# Altium schematic sheet Synaps_USB_V1I2.SchDoc
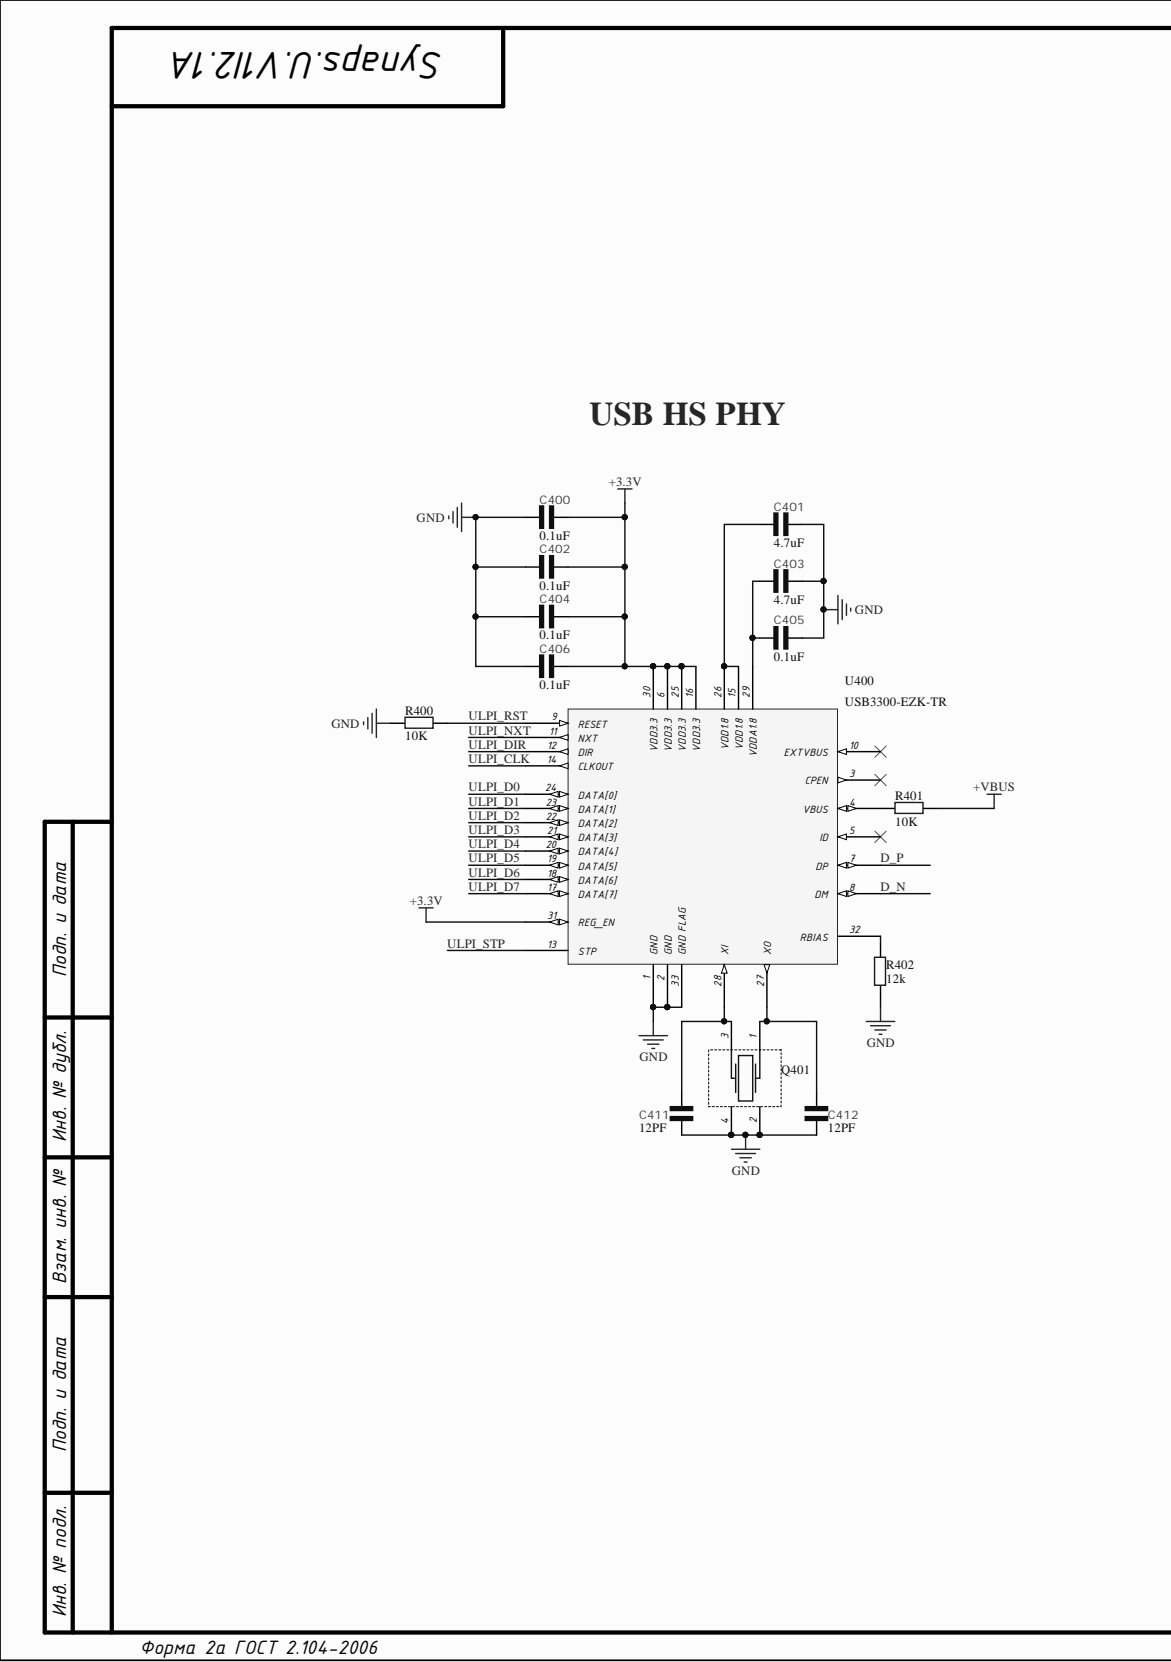
[diagram p0: Synaps_USB_V1I2.SchDoc, left]
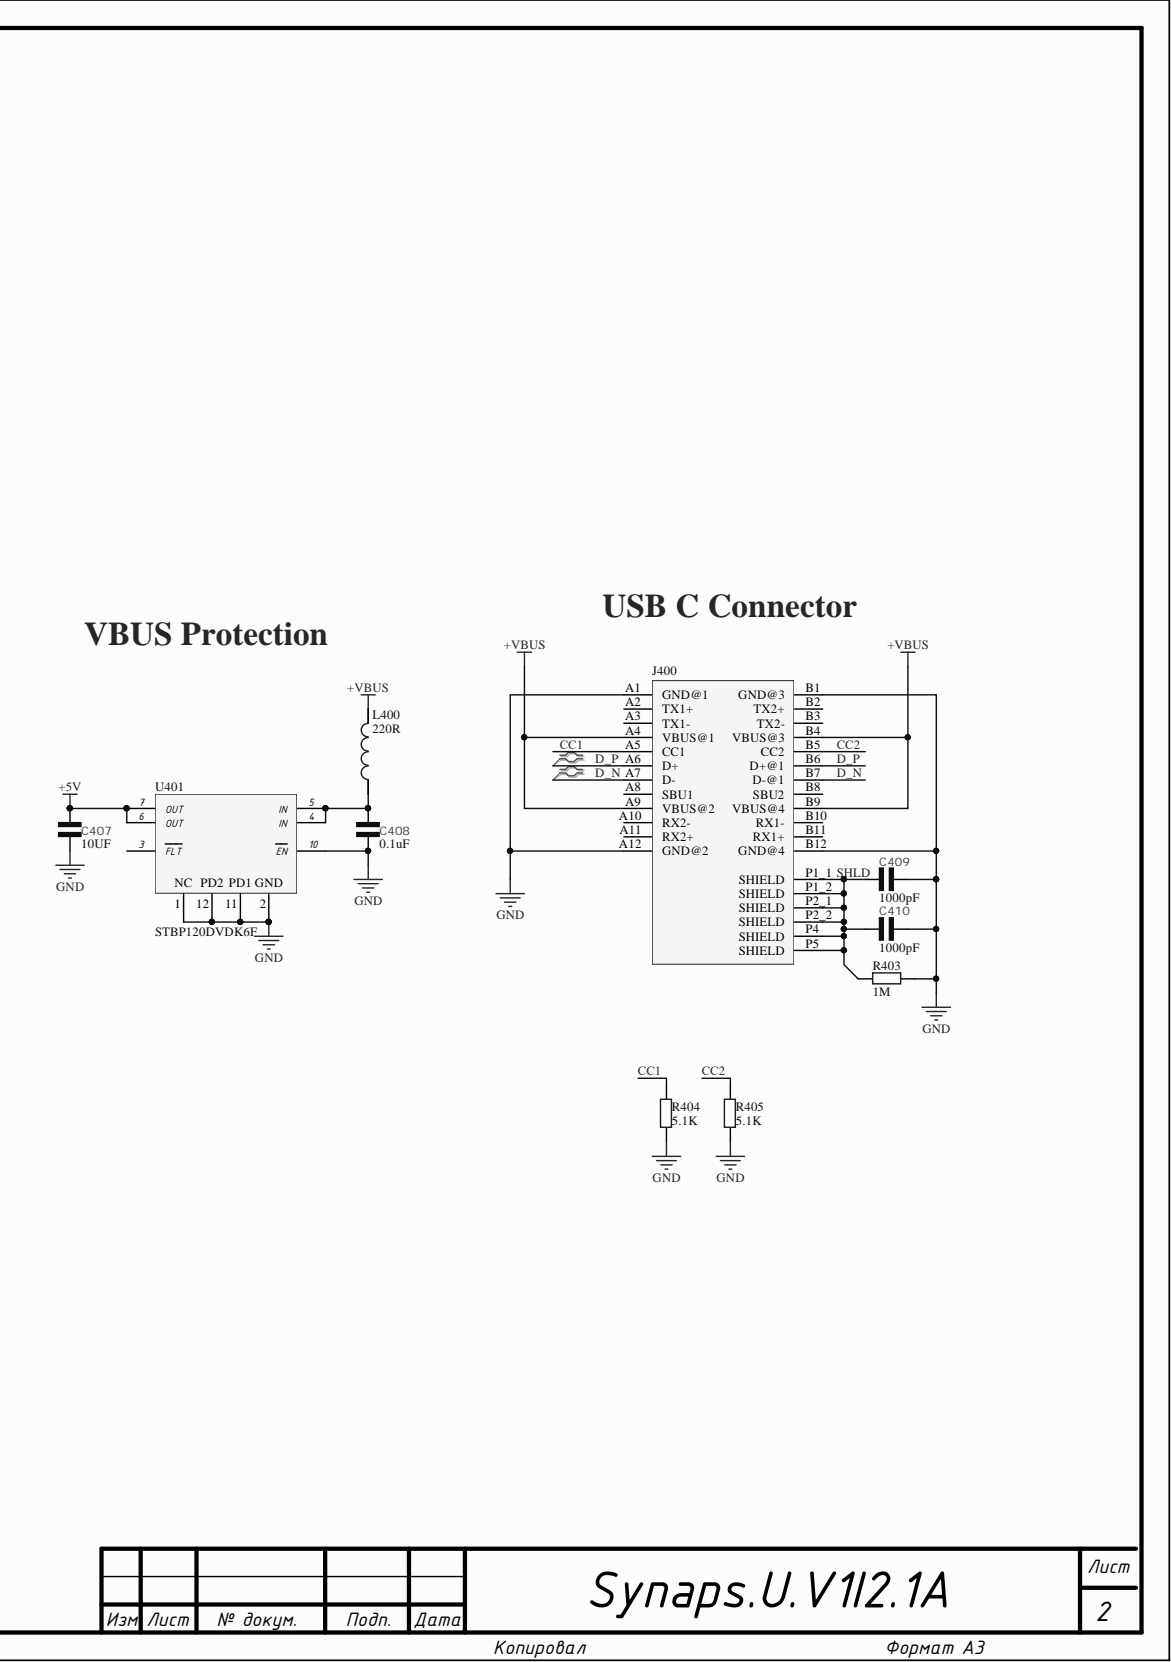
[diagram p1: Synaps_USB_V1I2.SchDoc, right]

## Parts
C400  value 0.1uF  [C0402]  (hidden comment: GRM155R71A104KA01D)
C401  value 4.7uF  Capacitance: 4.7uF  [C0603]  (hidden comment: CEJMK107BJ475KA-T)
C402  value 0.1uF  [C0402]  (hidden comment: GRM155R71A104KA01D)
C403  value 4.7uF  Capacitance: 4.7uF  [C0603]  (hidden comment: CEJMK107BJ475KA-T)
C404  value 0.1uF  [C0402]  (hidden comment: GRM155R71A104KA01D)
C405  value 0.1uF  [C0402]  (hidden comment: GRM155R71A104KA01D)
C406  value 0.1uF  [C0402]  (hidden comment: GRM155R71A104KA01D)
C407  value 10UF  [C1206]  (hidden comment: CL31B106KAHNNNE)
C408  value 0.1uF  [C0402]  (hidden comment: GRM155R71A104KA01D)
C409  value 1000pF  Capacitance: 1000pF  [C1206]  (hidden comment: 202R18W102KV4E)
C410  value 1000pF  Capacitance: 1000pF  [C1206]  (hidden comment: 202R18W102KV4E)
C411  value 12PF  [C0603]  (hidden comment: CL10C120JB8NNNC)
C412  value 12PF  [C0603]  (hidden comment: CL10C120JB8NNNC)
J400  2295018-2  [USB-C-1]  (value not drawn)
L400  value 220R  [L0603]  (hidden comment: CIC10P221NC)
Q401  XRCGB24M000F3M00R0  [XRCGB]  (value not drawn)
R400  value 10K  [R0402]  (hidden comment: RC0402FR-0710KL)
R401  value 10K  [R0402]  (hidden comment: RC0402FR-0710KL)
R402  value 12k  [R0402]  (hidden comment: AC0402FR-0712KL)
R403  value 1M  Resistance (Ohms): 1M  [R0603]  (hidden comment: RC0603FR-071ML)
R404  value 5.1K  [R0603]  (hidden comment: RC0603FR-075K1L)
R405  value 5.1K  [R0603]  (hidden comment: RC0603FR-075K1L)
U400  USB3300-EZK-TR  [QFN32-5x5]
U401  STBP120DVDK6F  [TDFN10-2.5x2.0x0.75p0.50]

## Nets
+3.3V: C400.2 C402.2 C404.2 C406.2 U400.6(VDD3.3) U400.16(VDD3.3) U400.25(VDD3.3) U400.30(VDD3.3) U400.31(REG_EN)
+5V: C407.2 U401.6(OUT) U401.7(OUT)
+VBUS: J400.A4(VBUS@1) J400.A9(VBUS@2) J400.B4(VBUS@3) J400.B9(VBUS@4) L400.2 R401.2
GND: C400.1 C401.2 C402.1 C403.2 C404.1 C405.2 C406.1 C407.1 C408.1 C409.2 C410.2 C411.1 C412.1 J400.A1(GND@1) J400.A12(GND@2) J400.B1(GND@3) J400.B12(GND@4) Q401.2 Q401.4 R400.1 R402.1 R403.2 R404.1 R405.1 U400.1(GND) U400.2(GND) U400.33(GND) U401.1(NC) U401.2 U401.10(EN) U401.11 U401.12
D_N: J400.A7(D-) J400.B7(D-@1) U400.8(DM)
D_P: J400.A6(D+) J400.B6(D+@1) U400.7(DP)
SHLD: C409.1 C410.1 J400.P1_1(SHIELD) J400.P1_2(SHIELD) J400.P2_1(SHIELD) J400.P2_2(SHIELD) J400.P4(SHIELD) J400.P5(SHIELD) R403.1
ULPI_CLK: U400.14(CLKOUT)
ULPI_D0: U400.24(DATA[0])
ULPI_D1: U400.23(DATA[1])
ULPI_D2: U400.22(DATA[2])
ULPI_D3: U400.21(DATA[3])
ULPI_D4: U400.20(DATA[4])
ULPI_D5: U400.19(DATA[5])
ULPI_D6: U400.18(DATA[6])
ULPI_D7: U400.17(DATA[7])
ULPI_DIR: U400.12(DIR)
ULPI_NXT: U400.11(NXT)
ULPI_RST: R400.2 U400.9(RESET)
ULPI_STP: U400.13(STP)
СС1: J400.A5(CC1) R404.2
СС2: J400.B5(CC2) R405.2
NetC401_1: C401.1 U400.15(VDD1.8) U400.26(VDD1.8)
NetC403_1: C403.1 C405.1 U400.29(VDDA1.8)
NetC408_2: C408.2 L400.1 U401.4(IN) U401.5(IN)
NetC411_2: C411.2 Q401.3 U400.28(XI)
NetC412_2: C412.2 Q401.1 U400.27(XO)
NetR401_1: R401.1 U400.4(VBUS)
NetR402_2: R402.2 U400.32(RBIAS)

Not connected: J400 A2(TX1+) A3(TX1-) A8(SBU1) A10(RX2-) A11(RX2+) B2(TX2+) B3(TX2-) B8(SBU2) B10(RX1-) B11(RX1+); U400 3(CPEN) 5(ID) 10(EXTVBUS); U401 3(FLT)

## Library data of the parts
C400 C402 C404-C406 C408 = GRM155R71A104KA01D: CAP CER 0.1uF 10V X7R 0402; Manufacturer: Murata; Capacitance: 100nF; Database Table Name: Capacitors_chip; Tolerance: 10%; Category: Capacitors; Component Kind: Standard; ComponentLink1Description: Datasheet; ComponentLink1URL: https://datasheet.ciiva.com/18184/getdatasheetpartid-130330-18184636.pdf; Component Type: Standard; Cost: 0,002541; Designator: C?; Library Name: AIComponents.DbLib; Part Number: GRM155R71A104KA01D; Stock: 10000; Termination: SMD/SMT; Voltage: 10V
C401 C403 = CEJMK107BJ475KA-T: Cap Ceramic 4.7uF 6.3V X5R 10% Pad SMD 0603 85°C T/R; Manufacturer: Taiyo Yuden; Database Table Name: Capacitors_chip; Category: Capacitors; Component Kind: Standard; Component Type: Standard; Cost: 0,0044802; Designator: C?; Library Name: AIComponents.DbLib; Part Number: CEJMK107BJ475KA-T; Pin Count: 2; Stock: 3970; Temperature Coefficie: X5R; Tolerance: ±10%; Voltage: 6.3V
C407 = CL31B106KAHNNNE: CAP CER 10UF 25V X7R 1206; Manufacturer: SAMSUNG ELECTRO-MECHANICS AMERICA, INC; Capacitance: 10µF; Database Table Name: Capacitors_chip; Tolerance: ±10%; Applications: General Purpose; Category: Capacitors; Component Kind: Standard; ComponentLink1Description: Datasheet; ComponentLink1URL: http://www.samsungsem.com/kr/support/product-search/mlcc/__icsFiles/afieldfile/2016/08/18/S_CL31B106KAHNNNE.pdf; Component Type: Standard; Cost: 0,0157181192832308; Designator: C?; Features: -; Height - Seated (Max): -; Lead Spacing: -; Lead Style: -; Library Name: AIComponents.DbLib; Mounting Type: Surface Mount, MLCC; Operating Temperature: -55°C ~ 125°C; Package / Case: 1206 (3216 Metric); Part Number: CL31B106KAHNNNE; Pin Count: 2; Ratings: -; Size / Dimension: 0.126" L x 0.063" W (3.20mm x 1.60mm); Stock: 1914; Temperature Coefficie: X7R; Temperature Coefficient: X7R; Thickness (Max): 0.071" (1.80mm); Voltage: 25V; Voltage - Rated: 25V
C409 C410 = 202R18W102KV4E: CAP CER 1000PF 2KV X7R 1206; Manufacturer: Johanson Dielectrics Inc.; Database Table Name: Capacitors_chip; Tolerance: ±10%; Voltage - Rated: 2000V; Category: Capacitors; Component Kind: Standard; ComponentLink1Description: Datasheet; ComponentLink1URL: https://www.johansondielectrics.com/downloads/jdi-product-catalog.pdf; Component Type: Standard; Cost: 0; Library Name: AIComponents.DbLib; Part Number: 202R18W102KV4E; Pin Count: 2; Stock: 0; Temperature Coefficie: X7R; Temperature Coefficient: X7R; Voltage: 2kV
C411 C412 = CL10C120JB8NNNC: CAP CER 12PF 50V NP0 0603; Manufacturer: SAMSUNG ELECTRO-MECHANICS AMERICA, INC; Database Table Name: Capacitors_chip; Temperature Coefficient: C0G, NP0; Tolerance: ±5%; Applications: General Purpose; Capacitance: 12pF; Category: Capacitors; Component Kind: Standard; ComponentLink1Description: Datasheet; ComponentLink1URL: http://www.samsungsem.com/kr/support/product-search/mlcc/__icsFiles/afieldfile/2016/08/18/S_CL10C120JB8NNNC.pdf; Component Type: Standard; Cost: 0,00216226822322155; Designator: C?; Features: -; Height - Seated (Max): -; Lead Spacing: -; Lead Style: -; Library Name: AIComponents.DbLib; Mounting Type: Surface Mount, MLCC; Operating Temperature: -55°C ~ 125°C; Package / Case: 0603 (1608 Metric); Part Number: CL10C120JB8NNNC; Pin Count: 2; Ratings: -; Size / Dimension: 0.063" L x 0.031" W (1.60mm x 0.80mm); Stock: 4084; Temperature Coefficie: NP0; Thickness (Max): 0.035" (0.90mm); Voltage: 50V; Voltage - Rated: 50V
J400 = 2295018-2: SPLASH PROOF USB TYPE C REC, DUA; Manufacturer: TE Connectivity AMP Connectors; Centerline_Pitch: .5 mm[.019 in]; Database Table Name: Connectors; MF: TE Connectivity; Number_of_Positions: 24; Product_Type: Connector; Component Kind: Standard; ComponentLink1Description: Drawing; ComponentLink1URL: http://www.te.com/commerce/DocumentDelivery/DDEController?Action=srchrtrv&DocNm=2295018&DocType=Customer+Drawing&DocLang=English; Component Type: Standard; Designator: J?; Digi-Key_Part_Number: A124377CT-ND; Digi-Key_Purchase_URL: https://www.digikey.com/product-detail/en/te-connectivity-amp-connectors/2295018-2/A124377CT-ND/6047824?utm_source=snapeda&utm_medium=aggregator&utm_campaign=symbol; EU_RoHS_Compliance: Compliant; Library Name: AIComponents.DbLib; Link: http://www.te.com/usa-en/product-2295018-2.html; MP: 2295018-2; Package: None; Part Number: 2295018-2; Pin Count: 24; SnapEDA_Link: https://www.snapeda.com/parts/2295018-2/TE%20Connectivity%20AMP%20Connectors/view-part/?ref=snap
L400 = CIC10P221NC: FERRITE BEAD 220 OHM 0603 1LN; Manufacturer: Samsung; Current: 1.4A; Database Table Name: Inductivities; DC Resistance (DCR) (Max): 100 mOhm; Filter Type: Power Line; Impedance @ Frequency: 220 Ohm @ 100MHz; Number of Lines: 1; Category: Filters; Component Kind: Standard; ComponentLink1Description: http://www.samsungsem.com/kr/front/downloadcms.do?path=/kr/support/product-search/bead/__icsFiles/afieldfile/2014/11/13&fileName=S_CIC10J121NC.pdf; ComponentLink1URL: http://www.samsungsem.com/kr/front/downloadcms.do?path=/kr/support/product-search/bead/__icsFiles/afieldfile/2014/11/13&fileName=S_CIC10J121NC.pdf; Component Type: Standard; Cost: 0,00715; Current Rating (Max): 1.4A; Designator: FB?; Height (Max): 0.037" (0.95mm); Library Name: AIComponents.DbLib; Mounting Type: Surface Mount; Operating Temperature: -55°C ~ 125°C; Package / Case: 0603 (1608 Metric); Part Number: CIC10P221NC; Pin Count: 2; Pricing 2: 1=0.1, 10=0.062, 25=0.0568, 50=0.051, 100=0.0374, 250=0.0272, 500=0.02492, 1000=0.02153, 5000=0.017 (USD); Ratings: -; Size / Dimension: 0.063" L x 0.031" W (1.60mm x 0.80mm); Stock: 3583; Stock 2: 30743; Supplier 2: Digi-Key; Supplier Part Number 2: 490-9701-1-ND
Q401 = XRCGB24M000F3M00R0: CRYSTAL 24.0000MHZ 6PF SMD; Manufacturer: Murata Electronics North America; Database Table Name: Resonators; Value: 24MHz; Component Kind: Standard; ComponentLink1Description: Datasheet; ComponentLink1URL: https://www.murata.com/~/media/webrenewal/campaign/ads/america/timing/p79e.ashx?la=en-us; Component Type: Standard; Designator: Q?; Library Name: AIComponents.DbLib; Part Number: XRCGB24M000F3M00R0; Pin Count: 4
R400 R401 = RC0402FR-0710KL: RES SMD 10K OHM 1% 1/16W 0402; Manufacturer: Yageo; Database Table Name: Resistors-chip; Temperature Coefficient: 100ppm/°C; Category: Resistors; Component Kind: Standard; Component Type: Standard; Cost: 0,00066; Designator: R?; Library Name: AIComponents.DbLib; Manufacturer Part Number: RC0402FR-0710KL; Part Number: RC0402FR-0710KL; Pin Count: 2; Pricing 1: 1=0.1, 10=0.014, 100=0.0056, 1000=0.0025, 2500=0.0022, 5000=0.0018 (USD); Stock: 10000; Supplier 1: Digi-Key; Supplier Part Number 1: 311-10.0KLRDKR-ND; Tolerance: 1%
R402 = AC0402FR-0712KL: RES SMD 12K OHM 1% 1/16W 0402; Manufacturer: YAGEO; Database Table Name: Resistors-chip; Component Kind: Standard; Component Type: Standard; Cost: 0; Designator: R?; Library Name: AIComponents.DbLib; Part Number: AC0402FR-0712KL; Pin Count: 2; Power: 1/16W; Stock: 0; Tolerance: 1%
R403 = RC0603FR-071ML: RES SMD 1M OHM 1% 1/10W 0603; Manufacturer: YAGEO; Database Table Name: Resistors-chip; Power: 0.1W, 1/10W; Temperature Coefficient: ±100ppm/°C; Category: Resistors; Component Height: 0.45; Component Kind: Standard; Component Type: Standard; Cost: 0,000778834333415389; Designator: R?; Library Name: AIComponents.DbLib; Manufacturer Part Number: RC0603FR-071ML; Part Number: RC0603FR-071ML; Pin Count: 2; Pricing 1: 1=0.1, 10=0.013, 25=0.0092, 50=0.007, 100=0.0052, 250=0.00396, 500=0.00316, 1000=0.00233 (USD); Stock: 4898; Supplier 1: Digi-Key; Supplier Part Number 1: 311-1.00MHRCT-ND; Tolerance: 1%
R404 R405 = RC0603FR-075K1L: RES SMD 5.1K OHM 1% 1/10W 0603; Manufacturer: Yageo; Database Table Name: Resistors-chip; Temperature Coefficient: ±100ppm/°C; Category: Resistors; Component Kind: Standard; Component Type: Standard; Cost: 0,000826; Designator: R?; Library Name: AIComponents.DbLib; Manufacturer Part Number: RC0603FR-075K1L; Part Number: RC0603FR-075K1L; Pin Count: 2; Pricing 1: 1=0.1, 10=0.015, 100=0.006, 1000=0.00268, 2500=0.00233 (USD); Stock: 4985; Supplier 1: Digi-Key; Supplier Part Number 1: 311-5.10KHRCT-ND; Tolerance: ±1%
U400 = USB3300-EZK-TR: IC CONTROLLER USB 32QFN; Manufacturer: Microchip Technology; Database Table Name: IC_Modules; Category: Integrated Circuits (ICs); Component Kind: Standard; ComponentLink1Description: Datasheet; ComponentLink1URL: http://www.microchip.com/mymicrochip/filehandler.aspx?ddocname=en562663; Component Type: Standard; Cost: 0; Designator: U?; Library Name: AIComponents.DbLib; Part Number: USB3300-EZK-TR; Pin Count: 33; Stock: 0
U401 = STBP120DVDK6F: IC OVP W/THERMAL SHUTDOWN 10TDFN; Database Table Name: IC_Modules; Category: Integrated Circuits (ICs); Component Kind: Standard; Component Type: Standard; Cost: 0; Designator: U?; Library Name: AIComponents.DbLib; Part Number: STBP120DVDK6F; Stock: 0
